# Altium schematic sheet PSU.SchDoc
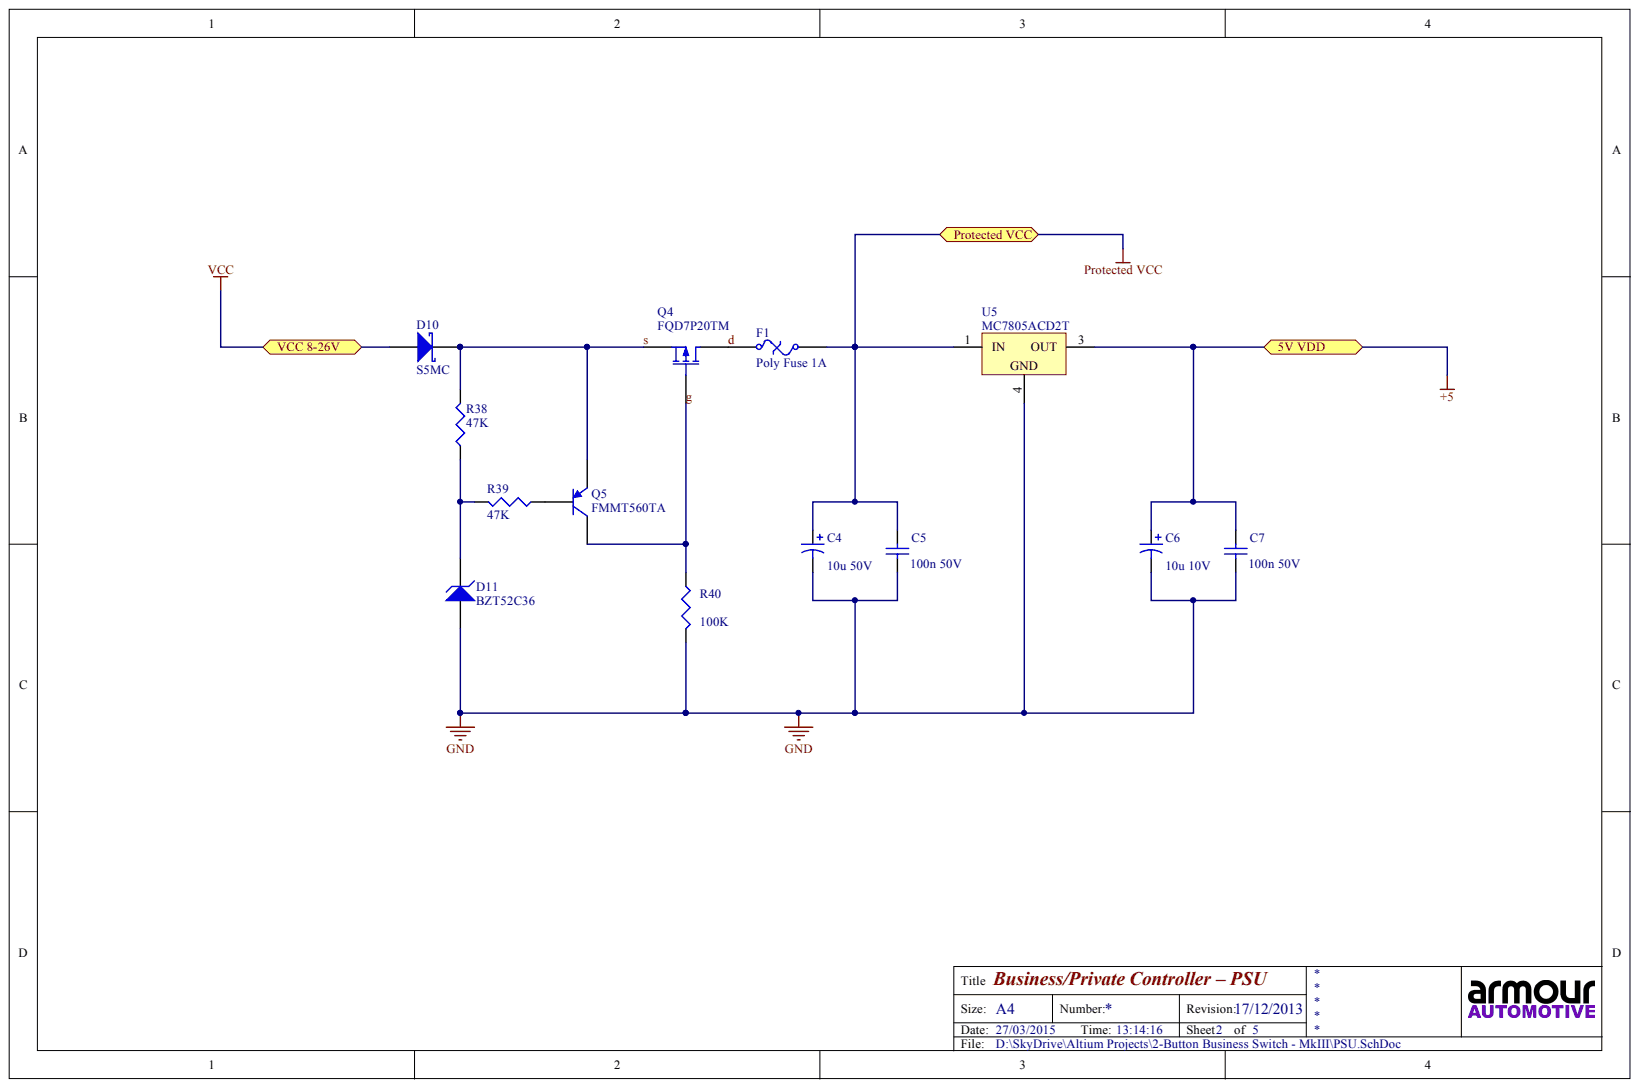
[diagram p0: PSU.SchDoc]

## Parts
C4  10u 50V  [1812]
C5  100n 50V  [1608[0603]]
C6  10u 10V  [1812]
C7  100n 50V  [1608[0603]]
D10  S5MC  [DIODE_SMC]
D11  BZT52C36  [SMB]
F1  Poly Fuse 1A  [SMB]
Q4  FQD7P20TM  [Super-D2Pak]
Q5  FMMT560TA  [SOT23]
R38  47K  [6-0805_M]
R39  47K  [6-0805_M]
R40  100K  [6-0805_M]
U5  MC7805ACD2T  [936-03]

## Ports
5V VDD, PROTECTED VCC, VCC 8-26V

## Nets
GND: C4.2 C5.2 C6.2 C7.2 D11.1 R40.2 U5.4
PROTECTED VCC: C4.1 C5.1 F1.2 U5.1 ; port PROTECTED VCC
+5: C6.1 C7.1 U5.3 ; port 5V VDD
D: F1.1 Q4.1
G: Q4.2 Q5.1 R40.1
S: D10.2 Q4.3 Q5.2 R38.2
VCC: D10.1 ; port VCC 8-26V
NetD11_2: D11.2 R38.1 R39.2
NetQ5_3: Q5.3 R39.1

## Library data of the parts
C4 C6 = Cap Pol3: Polarized Capacitor (Surface Mount); Value: 100pF; Component Kind: Standard; LatestRevisionDate: 29-May-2009; LatestRevisionNote: IPC-7351 Footprint Added.; Library Name: Miscellaneous Devices.IntLib; PackageDescription: Chip Capacitor; PackageReference: C0805; Published: 8-Jun-2000; Signal Integrity: Cap Pol; Simulation: CAP; Publisher: Altium Limited
C5 C7 = Cap Semi: Capacitor (Semiconductor SIM Model); Value: 100pF; Component Kind: Standard; LatestRevisionDate: 29-May-2009; LatestRevisionNote: IPC-7351 Footprint Added.; Library Name: Miscellaneous Devices.IntLib; PackageDescription: Chip Capacitor; PackageReference: C1206; Published: 8-Jun-2000; Signal Integrity: Cap Semi; Simulation: CAP; Publisher: Altium Limited
D10 = D Schottky: Schottky Diode; Code_JEDEC: DO-214-AA; Component Kind: Standard; LatestRevisionDate: 17-Jul-2002; LatestRevisionNote: Re-released for DXP Platform.; Library Name: Miscellaneous Devices.IntLib; PackageDescription: DO-214-AA/SMB; 2 C-Bend Leads; Body 5.3 x 3.6 mm, inc. leads (LxW); PackageReference: SMB; Published: 8-Jun-2000; Signal Integrity: D Schottky; Simulation: SKYDIODE; Publisher: Altium Limited
D11 = BZT52C36: 36V Surface Mount Zener Diode; Component Kind: Standard; ComponentLink1Description: Manufacturer Link; ComponentLink1URL: http://www.vishay.com/; DatasheetDocument: REV. L-2; LatestRevisionDate: 17-Jul-2002; LatestRevisionNote: Re-released for DXP Platform.; Library Name: Vishay Lite-On Discrete Diode.IntLib; PackageReference: SOD-123; Published: 8-Jun-2000; Signal Integrity: BZT52C36; Simulation: BZT52C36; Publisher: Altium Limited
F1 = Fuse Thermal: Thermal Fuse; Component Kind: Standard; LatestRevisionDate: 17-Jul-2002; LatestRevisionNote: Re-released for DXP Platform.; Library Name: Miscellaneous Devices.IntLib; PackageDescription: Thru-Hole; 2 Leads; PackageReference: PIN-W2/E2.8; Published: 24-Mar-1999; Publisher: Altium Limited
Q4 = MOSFET-P: P-Channel MOSFET; Component Kind: Standard; LatestRevisionDate: 17-Jul-2002; LatestRevisionNote: Re-released for DXP Platform.; Library Name: Miscellaneous Devices.IntLib; PackageDescription: TO, Flat Index; 3 In-Line, Axial Leads; Body Dia. 4.6mm; Lead Dia. 0.5 mm (max); PackageReference: E3; PackageVersion: 1995/1996; Published: 8-Jun-2000; Simulation: PMOS; Publisher: Altium Limited
Q5 = FMMT597: SOT23 PNP Silicon Planar High-Voltage Transistor; Component Kind: Standard; ComponentLink1Description: Manufacturer Link; ComponentLink1URL: http://www.zetex.com/; ComponentLink2Description: Datasheet; ComponentLink2URL: www.zetex.com/3.0/pdf/FMMT597.pdf; DatasheetVersion: Oct-1995; LatestRevisionDate: 17-Jul-2002; LatestRevisionNote: Re-released for DXP Platform.; Library Name: Zetex Discrete BJT.IntLib; PackageDescription: SOT-23; 3 Leads; Body 3.1 x 2.5 mm, inc. leads (LxW max), Alternate Lead Configuration; PackageReference: SOT23; PackageVersion: 1996; Published: 8-Jun-2000; Signal Integrity: FMMT597; Simulation: FMMT597; Publisher: Altium Limited
R38-R40 = Res3: Resistor; Value: 1K; Component Kind: Standard; LatestRevisionDate: 29-May-2009; LatestRevisionNote: IPC-7351 Footprint Added.; Library Name: Miscellaneous Devices.IntLib; PackageDescription: Chip Resistor; PackageReference: J1-0603; Published: 8-Jun-2000; Signal Integrity: Res; Simulation: RESISTOR; Publisher: Altium Limited
U5 = MC7805ACD2T: Three-Terminal Positive Voltage Regulator; Note: Pin 2 is electrically connected to Pin 4; Pin 2 is trimmed and not drawn;; Component Kind: Standard; ComponentLink1Description: Manufacturer Link; ComponentLink1URL: http://www.onsemi.com/site/products/taxonomy/0,4438,503,00.html; ComponentLink2Description: Datasheet; ComponentLink2URL: http://www.onsemi.com/pub/Collateral/MC7800-D.PDF; DatasheetVersion: 1997; Library Name: ON Semi Power Mgt Voltage Regulator.IntLib; PackageDescription: D2-PAK/TO-263-AB; 3 Leads (1 Cropped); Body 14.7 (inc. leads) x 10.2 mm (LxW max); PackageReference: 936-03; PackageVersion: 1997; Published: 15-Apr-2002; Publisher: Altium Limited
